# Altium schematic sheet SparkIO Thermal Shield - No Aux ADC.SchDoc
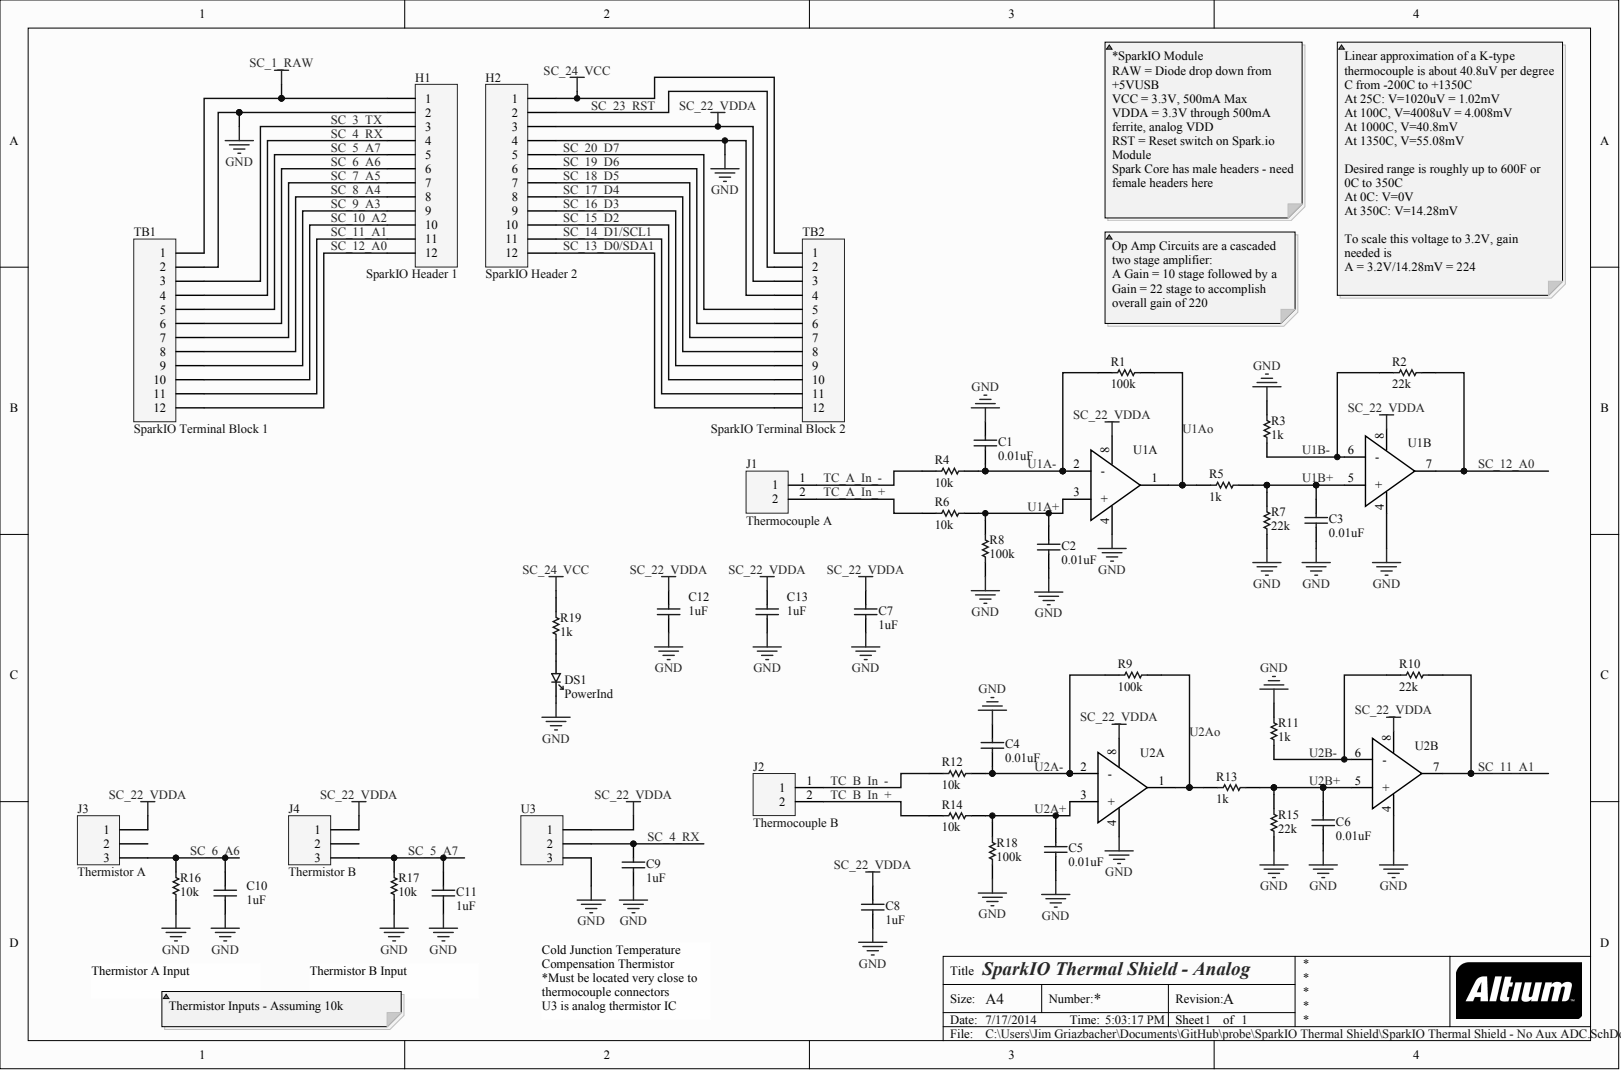
[diagram p0: SparkIO Thermal Shield - No Aux ADC.SchDoc]

## Parts
C1  value 0.01uF  (hidden comment: Capacitor)
C2  value 0.01uF  (hidden comment: Capacitor)
C3  value 0.01uF  (hidden comment: Capacitor)
C4  value 0.01uF  (hidden comment: Capacitor)
C5  value 0.01uF  (hidden comment: Capacitor)
C6  value 0.01uF  (hidden comment: Capacitor)
C7  value 1uF  (hidden comment: Capacitor)
C8  value 1uF  (hidden comment: Capacitor)
C9  value 1uF  (hidden comment: Capacitor)
C10  value 1uF  (hidden comment: Capacitor)
C11  value 1uF  (hidden comment: Capacitor)
C12  value 1uF  (hidden comment: Capacitor)
C13  value 1uF  (hidden comment: Capacitor)
DS1  PowerInd
H1  SparkIO Header 1
H2  SparkIO Header 2
J1  Thermocouple A
J2  Thermocouple B
J3  Thermistor A
J4  Thermistor B
R1  value 100k  (hidden comment: Resistor)
R2  value 22k  (hidden comment: Resistor)
R3  value 1k  (hidden comment: Resistor)
R4  value 10k  (hidden comment: Resistor)
R5  value 1k  (hidden comment: Resistor)
R6  value 10k  (hidden comment: Resistor)
R7  value 22k  (hidden comment: Resistor)
R8  value 100k  (hidden comment: Resistor)
R9  value 100k  (hidden comment: Resistor)
R10  value 22k  (hidden comment: Resistor)
R11  value 1k  (hidden comment: Resistor)
R12  value 10k  (hidden comment: Resistor)
R13  value 1k  (hidden comment: Resistor)
R14  value 10k  (hidden comment: Resistor)
R15  value 22k  (hidden comment: Resistor)
R16  value 10k  (hidden comment: Resistor)
R17  value 10k  (hidden comment: Resistor)
R18  value 100k  (hidden comment: Resistor)
R19  value 1k  (hidden comment: Resistor)
TB1  SparkIO Terminal Block 1
TB2  SparkIO Terminal Block 2
U1  OPAMP dual  (value not drawn)  (parts A,B)
U2  OPAMP dual  (value not drawn)  (parts A,B)
U3  Header 3  (value not drawn)

## Nets
GND: C1.1 C2.2 C3.2 C4.1 C5.2 C6.2 C7.2 C8.2 C9.2 C10.2 C11.2 C12.2 C13.2 DS1.2 H1.2 H2.4 R3.1 R7.1 R8.1 R11.1 R15.1 R16.2 R17.2 R18.1 TB1.2 TB2.4 U1.4 U2.4 U3.3
SC_1_RAW: H1.1 TB1.1
SC_22_VDDA: C7.1 C8.1 C12.1 C13.1 H2.3 J3.1 J4.1 TB2.3 U1.8 U2.8 U3.1
SC_24_VCC: H2.1 R19.1 TB2.1
SC_3_TX: H1.3 TB1.3
SC_4_RX: C9.1 H1.4 TB1.4 U3.2
SC_5_A7: C11.1 H1.5 J4.3 R17.1 TB1.5
SC_6_A6: C10.1 H1.6 J3.3 R16.1 TB1.6
SC_7_A5: H1.7 TB1.7
SC_8_A4: H1.8 TB1.8
SC_9_A3: H1.9 TB1.9
SC_10_A2: H1.10 TB1.10
SC_11_A1: H1.11 R10.1 TB1.11 U2.7
SC_12_A0: H1.12 R2.1 TB1.12 U1.7
SC_13_D0/SDA1: H2.12 TB2.12
SC_14_D1/SCL1: H2.11 TB2.11
SC_15_D2: H2.10 TB2.10
SC_16_D3: H2.9 TB2.9
SC_17_D4: H2.8 TB2.8
SC_18_D5: H2.7 TB2.7
SC_19_D6: H2.6 TB2.6
SC_20_D7: H2.5 TB2.5
SC_23_RST: H2.2 TB2.2
TC_A_IN_+: J1.2 R6.2
TC_A_IN_-: J1.1 R4.2
TC_B_IN_+: J2.2 R14.2
TC_B_IN_-: J2.1 R12.2
U1A+: C2.1 R6.1 R8.2 U1.3(+)
U1A-: C1.2 R1.2 R4.1 U1.2(-)
U1AO: R1.1 R5.2 U1.1
U1B+: C3.1 R5.1 R7.2 U1.5
U1B-: R2.2 R3.2 U1.6
U2A+: C5.1 R14.1 R18.2 U2.3(+)
U2A-: C4.2 R9.2 R12.1 U2.2(-)
U2AO: R9.1 R13.2 U2.1
U2B+: C6.1 R13.1 R15.2 U2.5
U2B-: R10.2 R11.2 U2.6
NetDS1_1: DS1.1 R19.2

Not connected: J3 2; J4 2

## Library data of the parts
C1-C6 = (no symbol name): Manufacturer: TDK Corporation; Manufacturer Part Number: C1608X7R1E103K080AA; Name: CAP CER 10000PF 25V 10% X7R 0603; Power: 25V; Tolerance: 10%; Supplier Part Number: 445-5100-1-ND; Package: 0603; Datasheet Link: http://product.tdk.com/en/catalog/datasheets/mlcc_commercial_general_en.pdf; Supplier: Digikey
C7-C13 = (no symbol name): Manufacturer: TDK Corporation; Manufacturer Part Number: C1608X7R1C105K080AC; Name: CAP CER 1UF 16V 10% X7R 0603; Power: 16V; Tolerance: 10%; Supplier Part Number: 445-1604-1-ND; Package: 0603; Datasheet Link: http://product.tdk.com/en/catalog/datasheets/mlcc_commercial_general_en.pdf; Supplier: Digikey
DS1 = (no symbol name): Manufacturer: LiteOn; Manufacturer Part Number: LTST-C190KGKT; Name: Green LED 20mA 2V Vf; Value: Green; Datasheet Link: http://optoelectronics.liteon.com/upload/download/DS22-2000-074/S_110_LTST-C190KGKT.pdf; Package: 0603; Supplier: Digikey; Supplier Part Number: 160-1435-1-ND
H1 H2 = (no symbol name): Manufacturer: Sullins Interconnect; Manufacturer Part Number: PPTC121LFBN-RC; Name: CONN HEADER FEM 12POS .1" SGL TIN; Value: PPTC121LFBN-RC; Supplier: Digikey; Datasheet Link: http://www.sullinscorp.com/drawings/78_P(N)PxCxxxLFBN-RC,_10492-G.pdf; Package: TH; Supplier Part Number: S6100-ND
J1 J2 = (no symbol name): Manufacturer: Omega; Manufacturer Part Number: PCC-SMP-K; Name: K Type Thermocouple Mini Horizontal TH Connector; Value: PCC-SMP-K; Package: TH; Datasheet Link: http://www.farnell.com/datasheets/1789660.pdf; Supplier: Newark; Supplier Part Number: 01H0905
J3 J4 = (no symbol name): Manufacturer: CUI Inc; Manufacturer Part Number: MJ-2509N; Name: 3/32" Mono Audio Jack Right Angle TH; Value: MJ-2509N; Package: TH; Datasheet Link: http://www.cui.com/product/resource/mj-2509n.pdf; Supplier: Digikey; Supplier Part Number: CP-M2509N-ND
R1 R8 R9 R18 = (no symbol name): Manufacturer: Vishay; Manufacturer Part Number: CRCW0603100KFKEA; Tolerance: 1%; Power: 1/10W; Name: RES 100K OHM 1/10W 1% 0603 SMD; Supplier: Digikey; Supplier Part Number: 541-100KHCT-ND; Package: 0603; Datasheet Link: http://www.vishay.com/docs/20035/dcrcwe3.pdf
R2 R7 R10 R15 = (no symbol name): Manufacturer: Vishay; Manufacturer Part Number: CRCW060322K0FKEA; Tolerance: 1%; Power: 1/10W; Name: RES 22.0K OHM 1/10W 1% 0603 SMD; Supplier: Digikey; Supplier Part Number: 541-22.0KHCT-ND; Package: 0603; Datasheet Link: http://www.vishay.com/docs/20035/dcrcwe3.pdf
R3 R5 R11 R13 R19 = (no symbol name): Manufacturer: Vishay; Manufacturer Part Number: CRCW06031K00FKEA; Tolerance: 1%; Power: 1/10W; Name: RES 1.00K OHM 1/10W 1% 0603 SMD; Supplier: Digikey; Supplier Part Number: 541-1.00KHCT-ND; Package: 0603; Datasheet Link: http://www.vishay.com/docs/20035/dcrcwe3.pdf
R4 R6 R12 R14 R16 R17 = (no symbol name): Manufacturer: Vishay; Manufacturer Part Number: CRCW060310K0FKEA; Tolerance: 1%; Power: 1/10W; Name: RES 10.0K OHM 1/10W 1% 0603 SMD; Supplier: Digikey; Supplier Part Number: 541-10.0KHCT-ND; Package: 0603; Datasheet Link: http://www.vishay.com/docs/20035/dcrcwe3.pdf
TB1 TB2 = (no symbol name): Manufacturer: On Shore Technology; Manufacturer Part Number: OSTTE120104; Name: TERMINAL BLOCK 3.5MM 12POS PCB; Value: OSTTE120104; Supplier: Digikey; Datasheet Link: http://www.on-shore.com/sites/default/files/manuals/OSTTEXX0164.pdf; Package: TH; Supplier Part Number: ED2737-ND
U1 U2 = (no symbol name): Manufacturer: Texas Instruments; Manufacturer Part Number: OPA2376AIDR; Name: IC Op Amp Dual GBP 5.5MHz 8SOIC; Power: 2.2V to 5.5V; Value: OPA2376AIDR; Package: 8-SOIC; Datasheet Link: http://www.ti.com/lit/ds/symlink/opa2376.pdf; Supplier: Digikey; Supplier Part Number: 296-22564-1-ND
U3 = (no symbol name): Manufacturer: Microchip; Manufacturer Part Number: TC1046VNBTR; Name: Precision Temperature Sensing IC; Power: 2.7V to 4.4V; Tolerance: +/-0.5C; Value: TC1046; Package: SOT23-3; Datasheet Link: http://ww1.microchip.com/downloads/en/DeviceDoc/21496C.pdf; Supplier: Digikey; Supplier Part Number: TC1046VNBTRCT-ND
